# Altium schematic sheet 主板_1.SchDoc
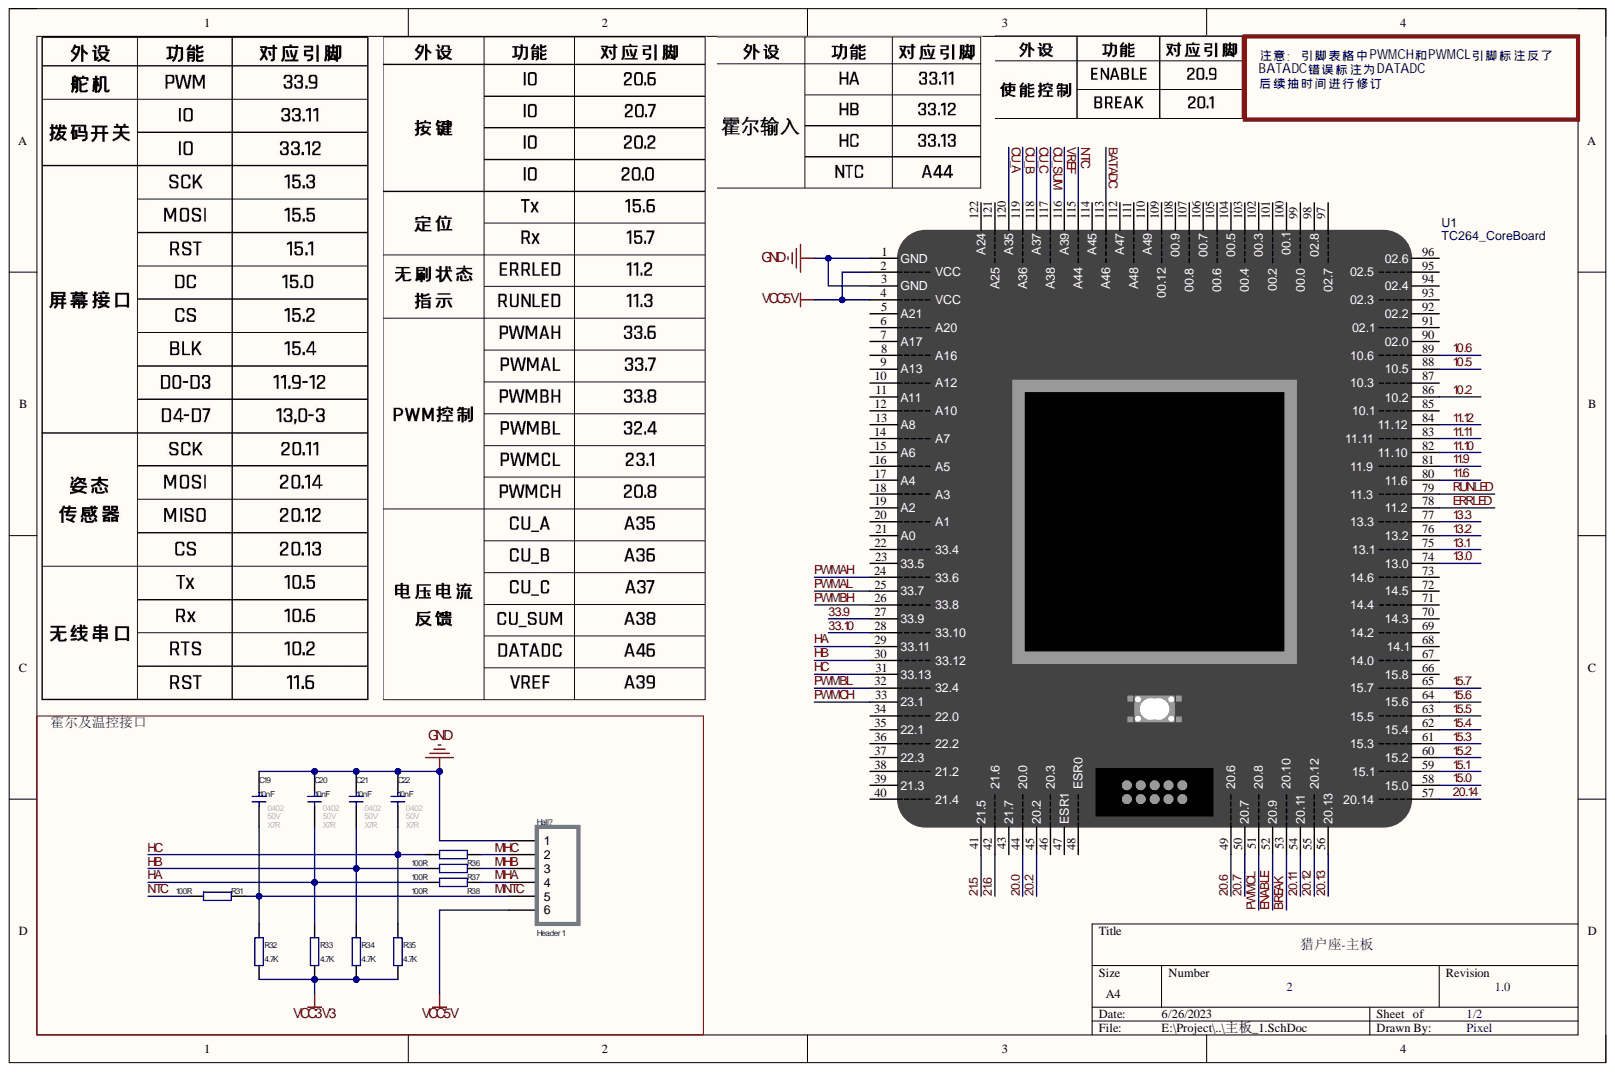
[diagram p0: 主板_1.SchDoc]

## Parts
C19  10nF 50V X7R  value 10nF  Type: X7R  Voltage: 50V  [0402]
C20  10nF 50V X7R  value 10nF  Type: X7R  Voltage: 50V  [0402]
C21  10nF 50V X7R  value 10nF  Type: X7R  Voltage: 50V  [0402]
C22  10nF 50V X7R  value 10nF  Type: X7R  Voltage: 50V  [0402]
Hall?  Header 1  [ZH1.5-6P-SH]
R31  value 100R  [RESC1005[0402]S-N]  (hidden comment: 100R ±1%)
R32  value 4.7K  [RESC1005[0402]S-N]  (hidden comment: 4.7K ±1%)
R33  value 4.7K  [RESC1005[0402]S-N]  (hidden comment: 4.7K ±1%)
R34  value 4.7K  [RESC1005[0402]S-N]  (hidden comment: 4.7K ±1%)
R35  value 4.7K  [RESC1005[0402]S-N]  (hidden comment: 4.7K ±1%)
R36  100R ±1%  value 100R  [RESC1005[0402]S-N]
R37  100R ±1%  value 100R  [RESC1005[0402]S-N]
R38  100R ±1%  value 100R  [RESC1005[0402]S-N]
U1  TC264_CoreBoard  [TC264_CoreBoard]

## Nets
GND: C19.2 C20.2 C21.2 C22.2 Hall?.1 U1.1(GND) U1.3(GND)
VCC3V3: R32.1 R33.1 R34.1 R35.1
VCC5V: Hall?.6 U1.2 U1.4
10.2: U1.86(10.2)
10.5: U1.88(10.5)
10.6: U1.89
11.6: U1.80(11.6)
11.9: U1.81
11.10: U1.82(11.10)
11.11: U1.83
11.12: U1.84(11.12)
13.0: U1.74(13.0)
13.1: U1.75
13.2: U1.76(13.2)
13.3: U1.77
15.0: U1.58(15.0)
15.1: U1.59
15.2: U1.60(15.2)
15.3: U1.61
15.4: U1.62(15.4)
15.5: U1.63
15.6: U1.64(15.6)
15.7: U1.65
20.0: U1.44
20.2: U1.45(20.2)
20.6: U1.49
20.7: U1.50(20.7)
20.11: U1.54(20.11)
20.12: U1.55
20.13: U1.56(20.13)
20.14: U1.57
21.5: U1.41(21.5)
21.6: U1.42
33.9: U1.27(33.9)
33.10: U1.28
BATADC: U1.113
BREAK: U1.53
CU_A: U1.120(A35)
CU_B: U1.119
CU_C: U1.118(A37)
CU_SUM: U1.117
ENABLE: U1.52(20.9)
ERRLED: U1.78(11.2)
HA: C20.1 R33.2 R38.1 U1.29(33.11)
HB: C21.1 R34.2 R37.1 U1.30
HC: C22.1 R35.2 R36.1 U1.31(33.13)
MHA: Hall?.4 R38.2
MHB: Hall?.3 R37.2
MHC: Hall?.2 R36.2
MNTC: C19.1 Hall?.5 R31.2 R32.2
NTC: R31.1 U1.115
PWMAH: U1.24
PWMAL: U1.25(33.7)
PWMBH: U1.26
PWMBL: U1.32
PWMCH: U1.33(23.1)
PWMCL: U1.51
RUNLED: U1.79
VREF: U1.116(A39)

Not connected: U1 5(A21) 6 7(A17) 8 9(A13) 10 11(A11) 12 13(A8) 14 15(A6) 16 17(A4) 18 19(A2) 20 21(A0) 22 23(33.5) 34 35(22.1) 36 37(22.3) 38 39(21.3) 40 43(21.7) 46 47(ESR1) 48 66(15.8) 67 68(14.1) 69 70(14.3) 71 72(14.5) 73 85 87 90(02.0) 91 92(02.2) 93 94(02.4) 95 96(02.6) 97 98(02.8) 99 100(00.1) 101 102(00.3) 103 104(00.5) 105 106(00.7) 107 108(00.9) 109 110(A49) 111 112(A47) 114(A45) 121 122(A24)

## Library data of the parts
C19-C22 = 10nF 0402 50V X7R: 贴片瓷片电容; MLCC; 0402(1005);; Component Kind: Standard; Revision: V1.0; Library Name: 无刷驱动-Infineon-AUIRS2181S-GTM-6S.SchLib
Hall? = Header 6: Header, 6-Pin; Component Kind: Standard; LatestRevisionDate: 17-Jul-2002; LatestRevisionNote: Re-released for DXP Platform.; Price(RMB): 0.00; Signal Integrity: Connector; Library Name: 无刷驱动-Infineon-AUIRS2181S-GTM-6S.SchLib; Publisher: Altium Limited
R31 R36-R38 = Res-Chip_5: 贴片电阻; Power: 1/8W; Component Kind: Standard; Revision: V1.0; Tolerance: ±1%; Library Name: 无刷驱动-Infineon-AUIRS2181S-GTM-6S.SchLib
R32-R35 = Res-Chip_4: 贴片电阻; Power: 1/8W; Component Kind: Standard; Revision: V1.0; Tolerance: ±1%; Library Name: 无刷驱动-Infineon-AUIRS2181S-GTM-6S.SchLib
U1 = TC264_CoreBoard: 逐飞科技TC264核心板; Component Kind: Standard; Library Name: TC264_主板_V2.6.SCHLIB
